# Altium schematic sheet [5] - Microphone, Sound and LEDs.SchDoc
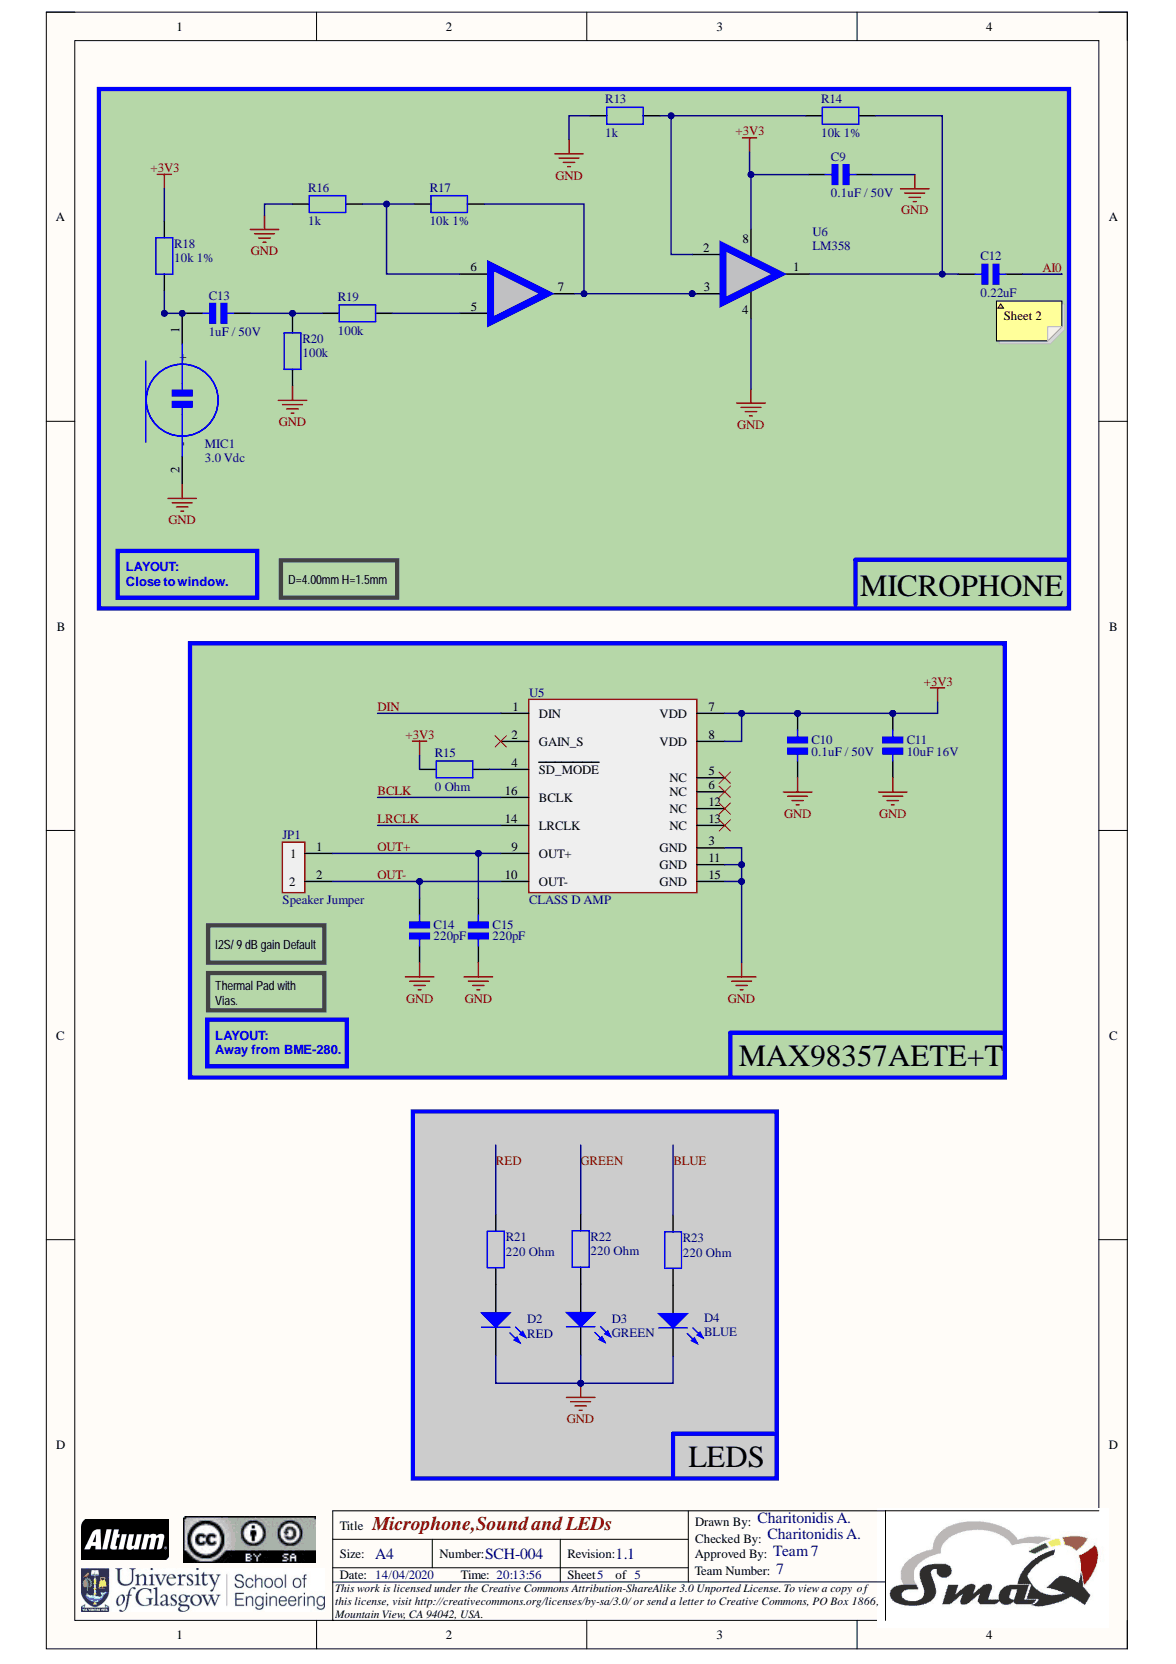
[diagram p0: [5] - Microphone, Sound and LEDs.SchDoc]

## Parts
C9  0.1uF / 50V  Voltage Rating: 50V  [C0805]  (no BOM)
C10  0.1uF / 50V  [C0805]  (no BOM)
C11  10uF 16V  [C0805]  (no BOM)
C12  0.22uF  [C0805]  (no BOM)
C13  1uF / 50V  Voltage: 50V  [C0805]  (no BOM)
C14  220pF  [C0805]  (no BOM)
C15  220pF  [C0805]  (no BOM)
D2  RED  [LED-1]  (no BOM)
D3  GREEN  [LED-1]  (no BOM)
D4  BLUE  [LED-1]  (no BOM)
JP1  Speaker Jumper  [Speaker Jumper]  (no BOM)
MIC1  3.0 Vdc  [Speaker Jumper]  (no BOM)
R13  1k  [R0805 (2012M)]  (no BOM)
R14  10k 1%  Tolerance: 1%  [R0805 (2012M)]  (no BOM)
R15  0 Ohm  [R0805 (2012M)]  (no BOM)
R16  1k  [R0805 (2012M)]  (no BOM)
R17  10k 1%  Tolerance: 1%  [R0805 (2012M)]  (no BOM)
R18  10k 1%  [R0805 (2012M)]  (no BOM)
R19  100k  [R0805 (2012M)]  (no BOM)
R20  100k  [R0805 (2012M)]  (no BOM)
R21  220 Ohm  [R0805 (2012M)]  (no BOM)
R22  220 Ohm  [R0805 (2012M)]  (no BOM)
R23  220 Ohm  [R0805 (2012M)]  (no BOM)
U5  CLASS D AMP  [16LTHINQFN]  (no BOM)
U6  LM358  [SOIC-8]  (no BOM)

## Nets
+3V3: C9.1 C10.2 C11.2 R15.2 R18.2 U5.7(VDD) U5.8(VDD) U6.8
GND: C9.2 C10.1 C11.1 C14.1 C15.1 D2.2 D3.2 D4.2 MIC1.2 R13.1 R16.1 R20.1 U5.3(GND) U5.11(GND) U5.15(GND) U6.4
AI0: C12.2
BCLK: U5.16(BCLK)
BLUE: R23.2
DIN: U5.1(DIN)
GREEN: R22.2
LRCLK: U5.14(LRCLK)
OUT+: C15.2 JP1.1 U5.9(OUT+)
OUT-: C14.2 JP1.2 U5.10(OUT-)
RED: R21.2
NetC12_1: C12.1 R14.2 U6.1
NetC13_1: C13.1 MIC1.1 R18.1
NetC13_2: C13.2 R19.2 R20.2
NetD2_1: D2.1 R21.1
NetD3_1: D3.1 R22.1
NetD4_1: D4.1 R23.1
NetR13_2: R13.2 R14.1 U6.2
NetR15_1: R15.1 U5.4(SD_MODE)
NetR16_2: R16.2 R17.2 U6.6
NetR17_1: R17.1 U6.3 U6.7
NetR19_1: R19.1 U6.5

Not connected: U5 2(GAIN_S) 5(NC) 6(NC) 12(NC) 13(NC)

## Library data of the parts
C9 C10 = 08055C104JAT4A: CAP CER 0.1UF 50V X7R 0805; Manufacturer 1: Kyocera AVX; Capacitance: 100nF; Category 1: Ceramic Capacitors; Tolerance: 5%; Case Code (Imperial): 0805; Case Code (Metric): 2012; Case/Package: 0805; ComponentLink1Description: https://datasheet.ciiva.com/16326/cx7r-16326437.pdf?src-supplier=Future+Electronics; ComponentLink1URL: https://datasheet.ciiva.com/16326/cx7r-16326437.pdf?src-supplier=Future+Electronics; ComponentLink2Description: https://datasheet.ciiva.com/6277/cx7r-6277722.pdf?src-supplier=Digi-Key; ComponentLink2URL: https://datasheet.ciiva.com/6277/cx7r-6277722.pdf?src-supplier=Digi-Key; Depth: 1.25mm; Description 1: CAP CER 0.1UF 50V X7R 0805; Dielectric: X7R; Lead Free: Lead Free; Length: 2.01mm; Manufacturer Part Number 1: 08055C104JAT4A; Max Operating Temperature: 125°C; Min Operating Temperature: -55°C; Mount: Surface Mount; Number of Pins: 2; Package Quantity: 10000; Packaging: Tape and Reel; Radiation Hardening: No; RoHS: Yes; Stock 1: 70357; Supplier 1: Mouser; Supplier Part Number 1: 581-08055C104JAT4A; Termination: SMD/SMT; Thickness: 0.037inch; Voltage Rating (DC): 50V; Width: 0.049inch; Library Name: Parts_Lib_SMAQ.SchLib
  C10: Voltage Rating: 50V
C11 = 0805YC106MAT2A: Manufacturer 1: Kyocera AVX; Manufacturer Part Number 1: 0805YC106MAT2A; RoHS: No; Stock 1: 1756; Supplier 1: Mouser; Supplier Part Number 1: 581-0805YC106MAT2A; Library Name: Parts_Lib_SMAQ.SchLib
C12 = 08053C224J4Z2A: Multilayer Ceramic Capacitors MLCC - SMD/SMT 25V 0.22uF X7R 0805 5% AEC-Q200; Manufacturer 1: Kyocera AVX; Manufacturer Part Number 1: 08053C224J4Z2A; RoHS: No; Stock 1: 37375; Supplier 1: Mouser; Supplier Part Number 1: 581-08053C224J4Z2A; Library Name: Parts_Lib_SMAQ.SchLib
C13 = 08055C105JAT2A: Manufacturer 1: Kyocera AVX; Category 1: Capacitors; Dielectric: X7R; Tolerance: 5%; Manufacturer Part Number 1: 08055C105JAT2A; RoHS: No; Stock 1: 5621; Supplier 1: Mouser; Supplier Part Number 1: 581-08055C105JAT2A; Library Name: Parts_Lib_SMAQ.SchLib
C14 C15 = 08055A221F4T2A: T&R / COMMERCIAL CHIP; Manufacturer 1: Kyocera AVX; Category 1: Ceramic Capacitors; Dielectric: C0G; Tolerance: 1%; Voltage Rating (DC): 50V; Capacitance: 220pF; Case/Package: 0805; Description 1: T&R / COMMERCIAL CHIP; Manufacturer Part Number 1: 08055A221F4T2A; RoHS: No; Stock 1: 6955; Supplier 1: Mouser; Supplier Part Number 1: 581-08055A221F4T2A; Library Name: Parts_Lib_SMAQ.SchLib
D2-D4 = LED1: Typical RED GaAs LED; LatestRevisionDate: 08-Jul-2005; LatestRevisionNote: Mech Layer 1 removed.; Library Name: Miscellaneous Devices.IntLib; PackageDescription: LED; 2 Leads; PackageReference: LED-1; Published: 8-Jun-2000; Simulation: LED1; Publisher: Altium Limited
MIC1 = CMC-4015-25L100: 4.0 mm, Omnidirectional, Wire Leads, 3.0 Vdc, IP65 Rated, Electret Condenser Microphone; Manufacturer 1: CUI Devices; ComponentLink1Description: http://datasheet.octopart.com/CMC-4015-25L100-CUI-Devices-datasheet-137937391.pdf?src-supplier=CUI+Devices; ComponentLink1URL: http://datasheet.octopart.com/CMC-4015-25L100-CUI-Devices-datasheet-137937391.pdf?src-supplier=CUI+Devices; Description 1: 4.0 mm, Omnidirectional, Wire Leads, 3.0 Vdc, IP65 Rated, Electret Condenser Microphone; Manufacturer Part Number 1: CMC-4015-25L100; Stock 1: 486; Supplier 1: Mouser; Supplier Part Number 1: 490-CMC-4015-25L100; Library Name: Parts_Lib_SMAQ.SchLib
R13 R16 = ERA-6AED102V: Res Thin Film 0805 1K Ohm 0.5% 1/8W ±25ppm/°C Molded SMD SMD Punched Carrier T/R; Manufacturer 1: Panasonic; Category 1: Chip SMD Resistors; Power Rating: 125mW; Resistance: 1kR; Voltage Rating: 100V; Case Code (Imperial): 0805; Case Code (Metric): 2012; Case/Package: 0805; ComponentLink1Description: https://datasheet.ciiva.com/9198/aoa0000ce26-9198987.pdf?src-supplier=Avnet; ComponentLink1URL: https://datasheet.ciiva.com/9198/aoa0000ce26-9198987.pdf?src-supplier=Avnet; Composition: Thin Film; Contact Plating: Tin; Depth: 1.25mm; Description 1: Res Thin Film 0805 1K Ohm 0.5% 1/8W ±25ppm/°C Molded SMD SMD Punched Carrier T/R; Height: 0.5mm; Lead Free: Lead Free; Length: 2mm; Manufacturer Part Number 1: ERA-6AED102V; Max Operating Temperature: 155°C; Military Standard: Not; Min Operating Temperature: -55°C; Mount: Surface Mount; Package Quantity: 5000; Packaging: Tape and Reel; RoHS: Yes; Stock 1: 18492; Supplier 1: Mouser; Supplier Part Number 1: 667-ERA-6AED102V; Temperature Coefficient: 25ppm/°C; Tolerance: 0.5%; Width: 1.25mm; Library Name: Parts_Lib_SMAQ.SchLib
R14 R17 R18 = AT0805FRE0710KL: RES SMD 10K OHM 1% 1/8W 0805; Manufacturer 1: Yageo; Category 1: Chip SMD Resistors; Max Power Dissipation: 125mW; Resistance: 10kR; Temperature Coefficient: 50ppm/°C; Case Code (Imperial): 0805; Case Code (Metric): 2012; Case/Package: 0805; ComponentLink1Description: http://www.yageo.com/documents/recent/PYu-AT_51_RoHS_L_5.pdf?src-supplier=Digi-Key; ComponentLink1URL: http://www.yageo.com/documents/recent/PYu-AT_51_RoHS_L_5.pdf?src-supplier=Digi-Key; ComponentLink2Description: http://www.yageo.com/documents/recent/PYu-AT_51_RoHS_L-3.pdf?src-supplier=Digi-Key; ComponentLink2URL: http://www.yageo.com/documents/recent/PYu-AT_51_RoHS_L-3.pdf?src-supplier=Digi-Key; Composition: Thin Film; Description 1: RES SMD 10K OHM 1% 1/8W 0805; Features: Moisture Resistant,Automotive AEC-Q200,Anti-Sulfur; Height: 0.024inch; Length: 0.079inch; Manufacturer Part Number 1: AT0805FRE0710KL; Max Operating Temperature: 155°C; Min Operating Temperature: -55°C; Number of Terminations: 2; Packaging: Tape and Reel; RoHS: Yes; Stock 1: 12821; Supplier 1: Mouser; Supplier Part Number 1: 603-AT0805FRE0710KL; Width: 0.049inch; Library Name: Parts_Lib_SMAQ.SchLib
  R18: Tolerance: 1%
R15 R21-R23 = ERJ-6GEY0R00V: RES SMD 0 OHM JUMPER 1/8W 0805; Manufacturer 1: Panasonic; Category 1: Chip SMD Resistors; Current Rating: 2A; Max Power Dissipation: 125mW; Resistance: 0mR; Temperature Coefficient: 600ppm/°C; Tolerance: 5%; Voltage Rating: 150V; Case Code (Imperial): 0805; Case Code (Metric): 2012; Case/Package: 0805; ComponentLink1Description: https://datasheet.ciiva.com/36526/1989607-36526237.pdf?src-supplier=Jameco; ComponentLink1URL: https://datasheet.ciiva.com/36526/1989607-36526237.pdf?src-supplier=Jameco; ComponentLink2Description: https://datasheet.ciiva.com/11821/0900766b80f806dd-11821735.pdf?src-supplier=RSComponents; ComponentLink2URL: https://datasheet.ciiva.com/11821/0900766b80f806dd-11821735.pdf?src-supplier=RSComponents; ComponentLink3Description: https://datasheet.ciiva.com/43460/1678796-43460073.pdf?src-supplier=Farnell; ComponentLink3URL: https://datasheet.ciiva.com/43460/1678796-43460073.pdf?src-supplier=Farnell; ComponentLink4Description: https://datasheet.ciiva.com/9117/aoa0000ce1-9117354.pdf?src-supplier=Avnet; ComponentLink4URL: https://datasheet.ciiva.com/9117/aoa0000ce1-9117354.pdf?src-supplier=Avnet; ComponentLink5Description: https://datasheet.ciiva.com/28695/1678796-28695877.pdf?src-supplier=Element14; ComponentLink5URL: https://datasheet.ciiva.com/28695/1678796-28695877.pdf?src-supplier=Element14; ComponentLink6Description: https://datasheet.ciiva.com/46377/1678796-46377866.pdf?src-supplier=Element14; ComponentLink6URL: https://datasheet.ciiva.com/46377/1678796-46377866.pdf?src-supplier=Element14; ComponentLink7Description: https://datasheet.ciiva.com/17215/aoa0000ce1-17215390.pdf?src-supplier=Avnet+Europe; ComponentLink7URL: https://datasheet.ciiva.com/17215/aoa0000ce1-17215390.pdf?src-supplier=Avnet+Europe; ComponentLink8Description: https://datasheet.ciiva.com/16512/aoa0000ce1-16512111.pdf?src-supplier=Avnet+Asia; ComponentLink8URL: https://datasheet.ciiva.com/16512/aoa0000ce1-16512111.pdf?src-supplier=Avnet+Asia; Composition: Thick Film; Contact Plating: Tin; Depth: 1.25mm; Description 1: RES SMD 0 OHM JUMPER 1/8W 0805; Features: Automotive AEC-Q200; Height: 0.6mm; Lead Free: Lead Free; Length: 2mm; Manufacturer Part Number 1: ERJ-6GEY0R00V; Max Operating Temperature: 155°C; Military Standard: Not; Min Operating Temperature: -55°C; Mount: Surface Mount; Number of Terminations: 2; Package Quantity: 1; Packaging: Tape and Reel; Power Rating: 125mW; Radiation Hardening: No; REACH SVHC: No SVHC; RoHS: Yes; Stock 1: 960895; Supplier 1: Mouser; Supplier Part Number 1: 667-ERJ-6GEY0R00V; Termination: SMD/SMT; Voltage Rating (DC): 150V; Width: 1.25mm; Library Name: Parts_Lib_SMAQ.SchLib
R19 R20 = ERJ-6ENF1003V: RES SMD 100K OHM 1% 1/8W 0805; Manufacturer 1: Panasonic; Category 1: Chip SMD Resistors; Max Power Dissipation: 125mW; Resistance: 100kR; Temperature Coefficient: 100ppm/°C; Voltage Rating: 150V; Case Code (Imperial): 0805; Case Code (Metric): 2012; Case/Package: 0805; ComponentLink1Description: https://datasheet.ciiva.com/11821/0900766b80f80784-11821507.pdf?src-supplier=RSComponents; ComponentLink1URL: https://datasheet.ciiva.com/11821/0900766b80f80784-11821507.pdf?src-supplier=RSComponents; ComponentLink2Description: https://datasheet.ciiva.com/27055/1988989-27055734.pdf?src-supplier=Jameco; ComponentLink2URL: https://datasheet.ciiva.com/27055/1988989-27055734.pdf?src-supplier=Jameco; ComponentLink3Description: https://datasheet.ciiva.com/17208/aoa0000ce2-17208153.pdf?src-supplier=Avnet+Europe; ComponentLink3URL: https://datasheet.ciiva.com/17208/aoa0000ce2-17208153.pdf?src-supplier=Avnet+Europe; ComponentLink4Description: https://datasheet.ciiva.com/24023/aoa0000ce2-24023497.pdf?src-supplier=Mouser; ComponentLink4URL: https://datasheet.ciiva.com/24023/aoa0000ce2-24023497.pdf?src-supplier=Mouser; ComponentLink5Description: https://datasheet.ciiva.com/7830/426256-7830746.pdf?src-supplier=Element14; ComponentLink5URL: https://datasheet.ciiva.com/7830/426256-7830746.pdf?src-supplier=Element14; ComponentLink6Description: https://datasheet.ciiva.com/16788/70260748-16788734.pdf?src-supplier=Allied+Electronics; ComponentLink6URL: https://datasheet.ciiva.com/16788/70260748-16788734.pdf?src-supplier=Allied+Electronics; ComponentLink7Description: https://datasheet.ciiva.com/48531/aoa0000ce2-48531486.pdf?src-supplier=Avnet; ComponentLink7URL: https://datasheet.ciiva.com/48531/aoa0000ce2-48531486.pdf?src-supplier=Avnet; ComponentLink8Description: https://datasheet.ciiva.com/22723/426256-22723909.pdf?src-supplier=Element14; ComponentLink8URL: https://datasheet.ciiva.com/22723/426256-22723909.pdf?src-supplier=Element14; ComponentLink9Description: https://datasheet.ciiva.com/46419/1678782-46419877.pdf?src-supplier=Element14; ComponentLink9URL: https://datasheet.ciiva.com/46419/1678782-46419877.pdf?src-supplier=Element14; ComponentLink10Description: https://datasheet.ciiva.com/16449/aoa0000ce2-16449480.pdf?src-supplier=Future+Electronics; ComponentLink10URL: https://datasheet.ciiva.com/16449/aoa0000ce2-16449480.pdf?src-supplier=Future+Electronics; ComponentLink11Description: https://datasheet.ciiva.com/22667/1678782-22667897.pdf?src-supplier=Element14; ComponentLink11URL: https://datasheet.ciiva.com/22667/1678782-22667897.pdf?src-supplier=Element14; ComponentLink12Description: https://datasheet.ciiva.com/30257/aoa0000ce2-30257690.pdf?src-supplier=Onlinecomponents.com; ComponentLink12URL: https://datasheet.ciiva.com/30257/aoa0000ce2-30257690.pdf?src-supplier=Onlinecomponents.com; ComponentLink13Description: https://datasheet.ciiva.com/7830/426256-7830988.pdf?src-supplier=Element14; ComponentLink13URL: https://datasheet.ciiva.com/7830/426256-7830988.pdf?src-supplier=Element14; ComponentLink14Description: https://datasheet.ciiva.com/16776/70260748-16776892.pdf?src-supplier=Allied+Electronics; ComponentLink14URL: https://datasheet.ciiva.com/16776/70260748-16776892.pdf?src-supplier=Allied+Electronics; Composition: Thick Film; Contact Plating: Tin; Depth: 1.25mm; Description 1: RES SMD 100K OHM 1% 1/8W 0805; Features: Automotive AEC-Q200; Height: 0.6mm; Lead Free: Lead Free; Length: 2mm; Manufacturer Part Number 1: ERJ-6ENF1003V; Max Operating Temperature: 155°C; Military Standard: Not; Min Operating Temperature: -55°C; Mount: Surface Mount; Number of Pins: 2; Number of Terminations: 2; Package Quantity: 1; Packaging: Tape and Reel; Power Rating: 125mW; Radiation Hardening: No; REACH SVHC: No SVHC; RoHS: Yes; Stock 1: 298428; Supplier 1: Mouser; Supplier Part Number 1: 667-ERJ-6ENF1003V; Termination: SMD/SMT; Tolerance: 1%; Voltage Rating (DC): 150V; Width: 0.049inch; Library Name: Parts_Lib_SMAQ.SchLib
U5 = MAX98357AETE+T: IC AUDIO AMP CLASS D 16-TQFN; Manufacturer 1: Maxim; Category 1: Amplifiers - Audio; Max Output Current: 1.6A; Max Output Power: 3.2W; Max Power Dissipation: 1.666W; Max Supply Voltage: 5.5V; Min Supply Voltage: 2.5V; Power Supply Rejection Ratio (PSRR): 77dB; Voltage Gain: 15dB; ComponentLink1Description: https://datasheet.ciiva.com/29970/max98357a-max98357b-29970280.pdf?src-supplier=Avnet; ComponentLink1URL: https://datasheet.ciiva.com/29970/max98357a-max98357b-29970280.pdf?src-supplier=Avnet; Contact Plating: Tin,Matte; Description 1: IC AUDIO AMP CLASS D 16-TQFN; Manufacturer Part Number 1: MAX98357AETE+T; Max Operating Temperature: 85°C; Min Operating Temperature: -40°C; Mount: Surface Mount; Number of Pins: 16; Packaging: Tape and Reel; Radiation Hardening: No; RoHS: Yes; Stock 1: 6162; Supplier 1: Mouser; Supplier Part Number 1: 700-MAX98357AETE+T; Library Name: Parts_Lib_SMAQ.SchLib
U6 = LM358DR: IC OPAMP GP 700KHZ 8SOIC; Manufacturer 1: Texas Instruments; Category 1: Amplifiers - Op Amps, Buffer, Instrumentation; Common Mode Rejection Ratio: 65dB; Dual Supply Voltage: 9V; Input Bias Current: 250nA; Input Offset Voltage (Vos): 7mV; Max Dual Supply Voltage: 16V; Max Supply Voltage: 32V; Min Dual Supply Voltage: 1.5V; Min Supply Voltage: 3V; Nominal Supply Current: 1.2mA; Number of Amplifiers: 2; Operating Supply Current: 1mA; Output Current: 30mA; Slew Rate: 0.3V/µs; Voltage Gain: 100dB; Bandwidth: 700kHz; Case/Package: SOIC; ComponentLink1Description: https://datasheet.ciiva.com/1795/lm358-1795488.pdf?src-supplier=Digi-Key; ComponentLink1URL: https://datasheet.ciiva.com/1795/lm358-1795488.pdf?src-supplier=Digi-Key; ComponentLink2Description: https://datasheet.ciiva.com/27056/2016330-27056228.pdf?src-supplier=Jameco; ComponentLink2URL: https://datasheet.ciiva.com/27056/2016330-27056228.pdf?src-supplier=Jameco; ComponentLink3Description: https://datasheet.ciiva.com/26847/lm358dr-26847142.pdf?src-supplier=IIIC; ComponentLink3URL: https://datasheet.ciiva.com/26847/lm358dr-26847142.pdf?src-supplier=IIIC; ComponentLink4Description: https://datasheet.ciiva.com/2973/lm358a-2973386.pdf?src-supplier=Avnet; ComponentLink4URL: https://datasheet.ciiva.com/2973/lm358a-2973386.pdf?src-supplier=Avnet; ComponentLink5Description: https://datasheet.ciiva.com/46390/1874566-46390414.pdf?src-supplier=Element14; ComponentLink5URL: https://datasheet.ciiva.com/46390/1874566-46390414.pdf?src-supplier=Element14; ComponentLink6Description: https://datasheet.ciiva.com/7709/1874566-7709823.pdf?src-supplier=Element14; ComponentLink6URL: https://datasheet.ciiva.com/7709/1874566-7709823.pdf?src-supplier=Element14; ComponentLink7Description: https://datasheet.ciiva.com/1795/lm358-1795489.pdf?src-supplier=Digi-Key; ComponentLink7URL: https://datasheet.ciiva.com/1795/lm358-1795489.pdf?src-supplier=Digi-Key; ComponentLink8Description: https://datasheet.ciiva.com/46385/1874566-46385851.pdf?src-supplier=Element14; ComponentLink8URL: https://datasheet.ciiva.com/46385/1874566-46385851.pdf?src-supplier=Element14; ComponentLink9Description: https://datasheet.ciiva.com/1795/lm358-1795487.pdf?src-supplier=Digi-Key; ComponentLink9URL: https://datasheet.ciiva.com/1795/lm358-1795487.pdf?src-supplier=Digi-Key; Contact Plating: Gold; Description 1: IC OPAMP GP 700KHZ 8SOIC; Gain Bandwidth Product: 700kHz; Height: 1.58mm; Lead Free: Lead Free; Length: 4.9mm; Manufacturer Part Number 1: LM358DR; Max Operating Temperature: 70°C; Min Operating Temperature: 0°C; Mount: Surface Mount; Number of Channels: 2; Number of Circuits: 2; Number of Elements: 2; Number of Pins: 8; Operating Supply Voltage: 16V; Output Current per Channel: 30mA; Package Quantity: 2500; Packaging: Tape and Reel; Power Supply Rejection Ratio (PSRR): 65dB; Radiation Hardening: No; REACH SVHC: No SVHC; RoHS: Yes; Stock 1: 15836; Supplier 1: Mouser; Supplier Part Number 1: 595-LM358DR; Unity Gain Bandwidth Product: 700kHz; Width: 3.91mm; Library Name: Parts_Lib_SMAQ.SchLib
JP1 = Speaker Jumper: Library Name: Parts_Lib_SMAQ.SchLib
